# Altium schematic sheet POWER&USB.SchDoc(POWER&USB)
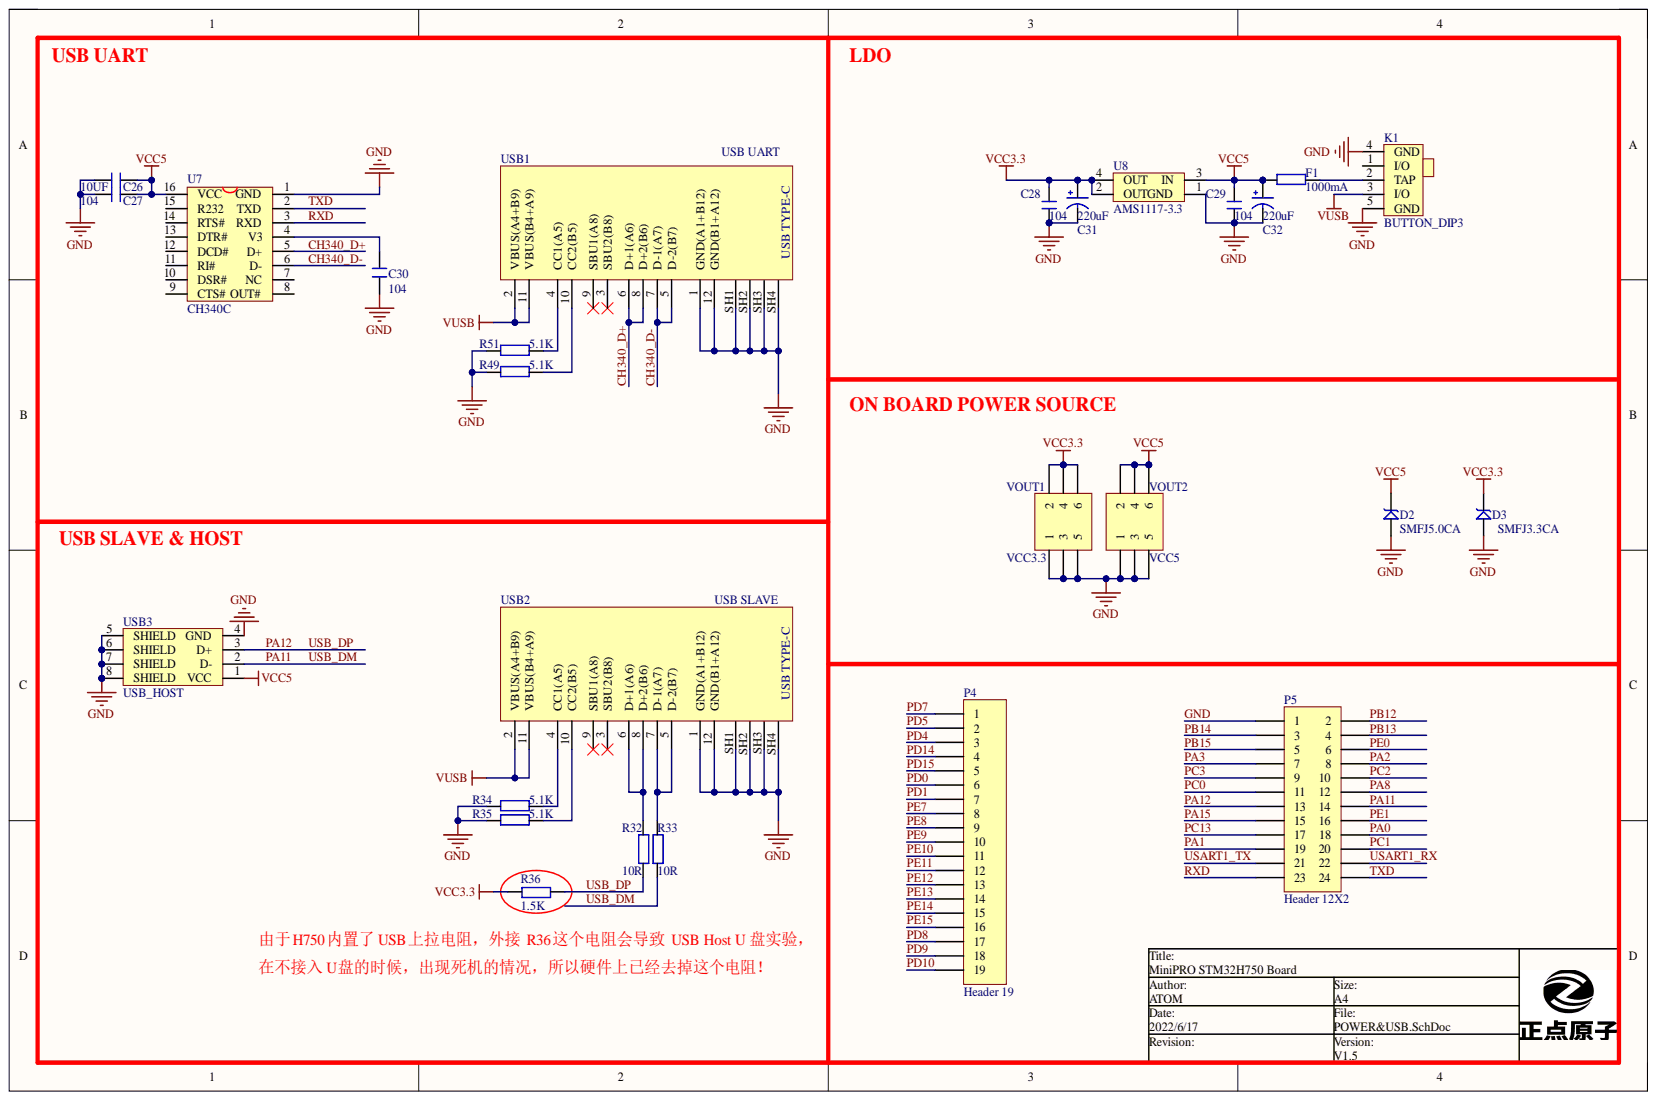
[diagram p0: POWER&USB.SchDoc]

## Parts
C26  10UF  [C 0603]
C27  104  [C 0402]
C28  104  [C 0402]
C29  104  [C 0402]
C30  104  [C 0402]
C31  220uF  [CC6.56.5]
C32  220uF  [CC6.56.5]
D2  SMFJ5.0CA  [SOD-123]
D3  SMFJ3.3CA  [SOD-123]
F1  1000mA  [0805]
K1  BUTTON_DIP3  [BTN8.8*4.6]
P4  Header 19  [HDR1X19]
P5  Header 12X2  [HDR2X12]
R32  10R  [0402]
R33  10R  [0402]
R34  5.1K  [0402]
R35  5.1K  [0402]
R36  1.5K  [0402]
R49  5.1K  [0402]
R51  5.1K  [0402]
U7  CH340C  [SOP16M]
U8  AMS1117-3.3  [AMS1117]
USB1  USB UART  [USB Type-C]
USB2  USB SLAVE  [USB Type-C]
USB3  USB_HOST  [USB-A-AF10-SOP]
VOUT1  VCC3.3  [HDR2X3]
VOUT2  VCC5  [HDR2X3]

## Nets
VCC3.3: C28.1 C31.1 D3.2 R36.1 U8.2(OUT) U8.4(OUT) VOUT1.2 VOUT1.4 VOUT1.6
VCC5: C26.2 C27.2 C29.1 C32.1 D2.2 F1.2 U7.16(VCC) U8.3(IN) USB3.1(VCC) VOUT2.2 VOUT2.4 VOUT2.6
VUSB: K1.3(I/O) USB1.2(VBUS(A4+B9)) USB1.11(VBUS(B4+A9)) USB2.2(VBUS(A4+B9)) USB2.11(VBUS(B4+A9))
CH340_D+: U7.5(D+) USB1.6(D+1(A6)) USB1.8(D+2(B6))
CH340_D-: U7.6(D-) USB1.5(D-2(B7)) USB1.7(D-1(A7))
GND: C26.1 C27.1 C28.2 C29.2 C30.1 C31.2 C32.2 D2.1 D3.1 K1.4(GND) K1.5(GND) P5.1 R34.2 R35.2 R49.2 R51.2 U7.1(GND) U8.1(GND) USB1.1(GND(A1+B12)) USB1.12(GND(B1+A12)) USB1.SH1(Shield1) USB1.SH2(Shield2) USB1.SH3(Shield3) USB1.SH4(Shield4) USB2.1(GND(A1+B12)) USB2.12(GND(B1+A12)) USB2.SH1(Shield1) USB2.SH2(Shield2) USB2.SH3(Shield3) USB2.SH4(Shield4) USB3.4(GND) USB3.5(SHIELD) USB3.6(SHIELD) USB3.7(SHIELD) USB3.8(SHIELD) VOUT1.1 VOUT1.3 VOUT1.5 VOUT2.1 VOUT2.3 VOUT2.5
PA0: P5.18
PA1: P5.19
PA2: P5.8
PA3: P5.7
PA8: P5.12
PA11: P5.14 R33.1 USB3.2(D-)
PA12: P5.13 R32.1 R36.2 USB3.3(D+)
PA15: P5.15
PB12: P5.2
PB13: P5.4
PB14: P5.3
PB15: P5.5
PC0: P5.11
PC1: P5.20
PC2: P5.10
PC3: P5.9
PC13: P5.17
PD0: P4.6
PD1: P4.7
PD4: P4.3
PD5: P4.2
PD7: P4.1
PD8: P4.17
PD9: P4.18
PD10: P4.19
PD14: P4.4
PD15: P4.5
PE0: P5.6
PE1: P5.16
PE7: P4.8
PE8: P4.9
PE9: P4.10
PE10: P4.11
PE11: P4.12
PE12: P4.13
PE13: P4.14
PE14: P4.15
PE15: P4.16
RXD: P5.23 U7.3(RXD)
TXD: P5.24 U7.2(TXD)
USART1_RX: P5.22
USART1_TX: P5.21
NetC30_2: C30.2 U7.4(V3)
NetF1_1: F1.1 K1.2(TAP)
NetR32_2: R32.2 USB2.6(D+1(A6)) USB2.8(D+2(B6))
NetR33_2: R33.2 USB2.5(D-2(B7)) USB2.7(D-1(A7))
NetR34_1: R34.1 USB2.4(CC1(A5))
NetR35_1: R35.1 USB2.10(CC2(B5))
NetR49_1: R49.1 USB1.10(CC2(B5))
NetR51_1: R51.1 USB1.4(CC1(A5))

Not connected: K1 1(I/O); U7 7(NC) 8(OUT#) 9(CTS#) 10(DSR#) 11(RI#) 12(DCD#) 13(DTR#) 14(RTS#) 15(R232); USB1 3(SBU2(B8)) 9(SBU1(A8)); USB2 3(SBU2(B8)) 9(SBU1(A8))

## Library data of the parts
C26 C27 C30 = C: 无极性贴片电容; 材质: X7R; 版权归属: 源创客; BOM名称: 无极性贴片电容; Component Kind: Standard; Library Name: 3.1   - 电阻-电容-电感.SchLib; 学习资源: www.ooocke.com
C28 C29 = C: 无极性贴片电容; 版权归属: 源创客; BOM名称: 无极性贴片电容; Component Kind: Standard; Library Name: 电阻-电容-电感.SchLib; 学习资源: www.ooocke.com
C31 C32 = CAP: Component Kind: Standard; Library Name: MYCOMLIB.IntLib
D2 D3 = SMBJ: TVS; Component Kind: Standard; Library Name: ALIENTEK_COMPONENT.IntLib
F1 R32-R36 R49 R51 = R: Component Kind: Standard; Library Name: MYCOMLIB.IntLib
P4 = Header 19: Header, 19-Pin; Component Kind: Standard; LatestRevisionDate: 17-Jul-2002; LatestRevisionNote: Re-released for DXP Platform.; Library Name: Miscellaneous Connectors.IntLib; Signal Integrity: Connector; Publisher: Altium Limited
P5 = Header 12X2: Header, 12-Pin, Dual row; Component Kind: Standard; LatestRevisionDate: 17-Jul-2002; LatestRevisionNote: Re-released for DXP Platform.; Library Name: Miscellaneous Connectors.IntLib; Signal Integrity: Connector; Publisher: Altium Limited
U7 = CH340C: USB2UART; Component Kind: Standard; Library Name: ALIENTEK_COMPONENT.IntLib
U8 = AMS1117: Component Kind: Standard; Library Name: MYCOMLIB.IntLib
USB1 USB2 = USB Type-C: 12P TypeC 母座; Component Kind: Standard; Library Name: 接口类.SchLib
USB3 = USB-A-AF-SOP: Component Kind: Standard; Description: USB-A-AF-SOP; Library Name: ALIENTEK_COMPONENT.IntLib
VOUT1 VOUT2 = Header 3X2: Header, 3-Pin, Dual row; Component Kind: Standard; LatestRevisionDate: 17-Jul-2002; LatestRevisionNote: Re-released for DXP Platform.; Library Name: Miscellaneous Connectors.IntLib; Publisher: Altium Limited
K1 = BUTTON_DIP3: Component Kind: Standard; Library Name: ALIENTEK_COMPONENT.IntLib
